# Altium schematic sheet NAPaC_DetachableModule.SchDoc(NAPaC_DetachableModule)
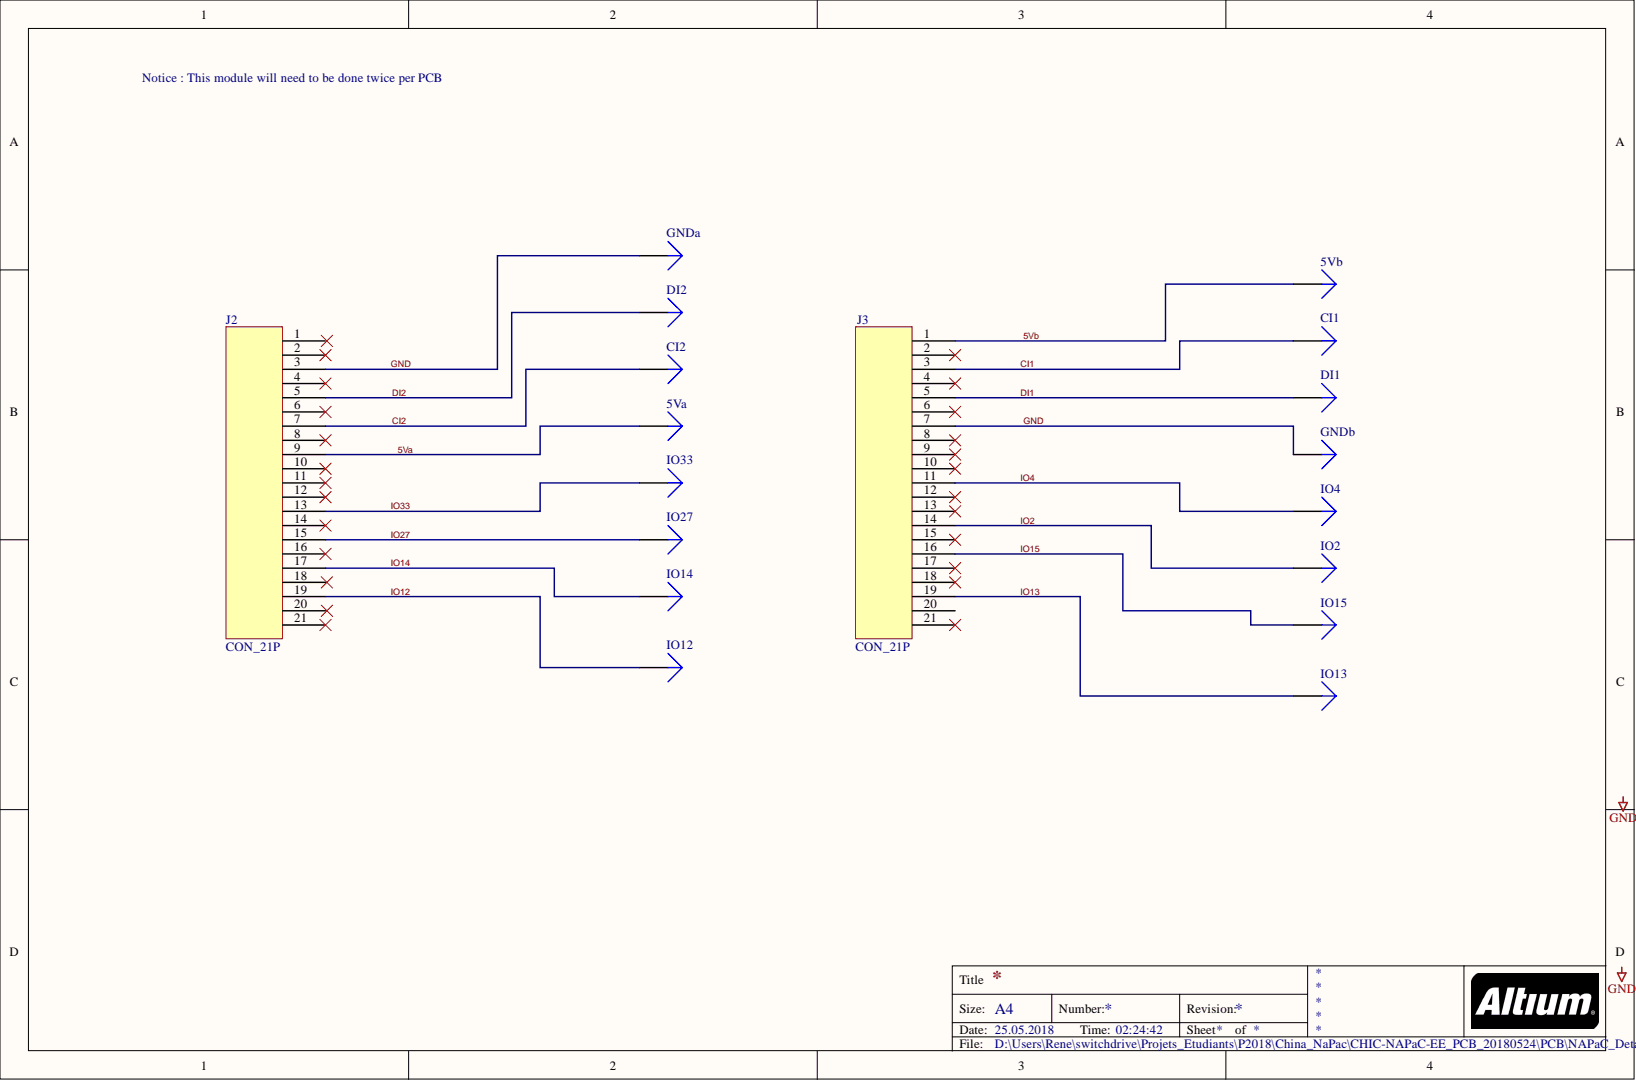
[diagram p0: NAPaC_DetachableModule.SchDoc]

## Parts
5Va  Plug  [PIN_1]  (value not drawn)
5Vb  Plug  [PIN_1]  (value not drawn)
CI1  Plug  [PIN_1]  (value not drawn)
CI2  Plug  [PIN_1]  (value not drawn)
DI1  Plug  [PIN_1]  (value not drawn)
DI2  Plug  [PIN_1]  (value not drawn)
GNDa  Plug  [PIN_1]  (value not drawn)
GNDb  Plug  [PIN_1]  (value not drawn)
IO2  Plug  [PIN_1]  (value not drawn)
IO4  Plug  [PIN_1]  (value not drawn)
IO12  Plug  [PIN_1]  (value not drawn)
IO13  Plug  [PIN_1]  (value not drawn)
IO14  Plug  [PIN_1]  (value not drawn)
IO15  Plug  [PIN_1]  (value not drawn)
IO27  Plug  [PIN_1]  (value not drawn)
IO33  Plug  [PIN_1]  (value not drawn)
J2  CON_21P  [PINS_HEADER-21]
J3  CON_21P  [PINS_HEADER-21]

## Nets
5Va: 5Va.1 J2.9
5Vb: 5Vb.1 J3.1
CI1: CI1.1 J3.3
CI2: CI2.1 J2.7
DI1: DI1.1 J3.5
DI2: DI2.1 J2.5
GND: GNDa.1 GNDb.1 J2.3 J3.7
IO2: IO2.1 J3.14
IO4: IO4.1 J3.11
IO12: IO12.1 J2.19
IO13: IO13.1 J3.19
IO14: IO14.1 J2.17
IO15: IO15.1 J3.16
IO27: IO27.1 J2.15
IO33: IO33.1 J2.13

Not connected: J2 1 2 4 6 8 10 11 12 14 16 18 20 21; J3 2 4 6 8 9 10 12 13 15 17 18 20 21

## Library data of the parts
J2 J3 = PINS_HEADER: 21 Positions Header, Breakaway Connector 0.100" (2.54mm) Through Hole Tin; Supplier Part Number 1: S1012EC-21-ND; Supplier 1: Digi-Key; Library Name: NAPaC_Schlib.SchLib; Component Kind: Standard
5Va 5Vb CI1 CI2 DI1 DI2 GNDa GNDb IO2 IO4 IO12-IO15 IO27 IO33 = Plug: Signal Integrity: Connector; Library Name: Miscellaneous Connectors.IntLib; LatestRevisionNote: Re-released for DXP Platform.; LatestRevisionDate: 17-Jul-2002; Description: Plug; Component Kind: Standard; Publisher: Altium Limited
